# Altium schematic sheet Top.SchDoc
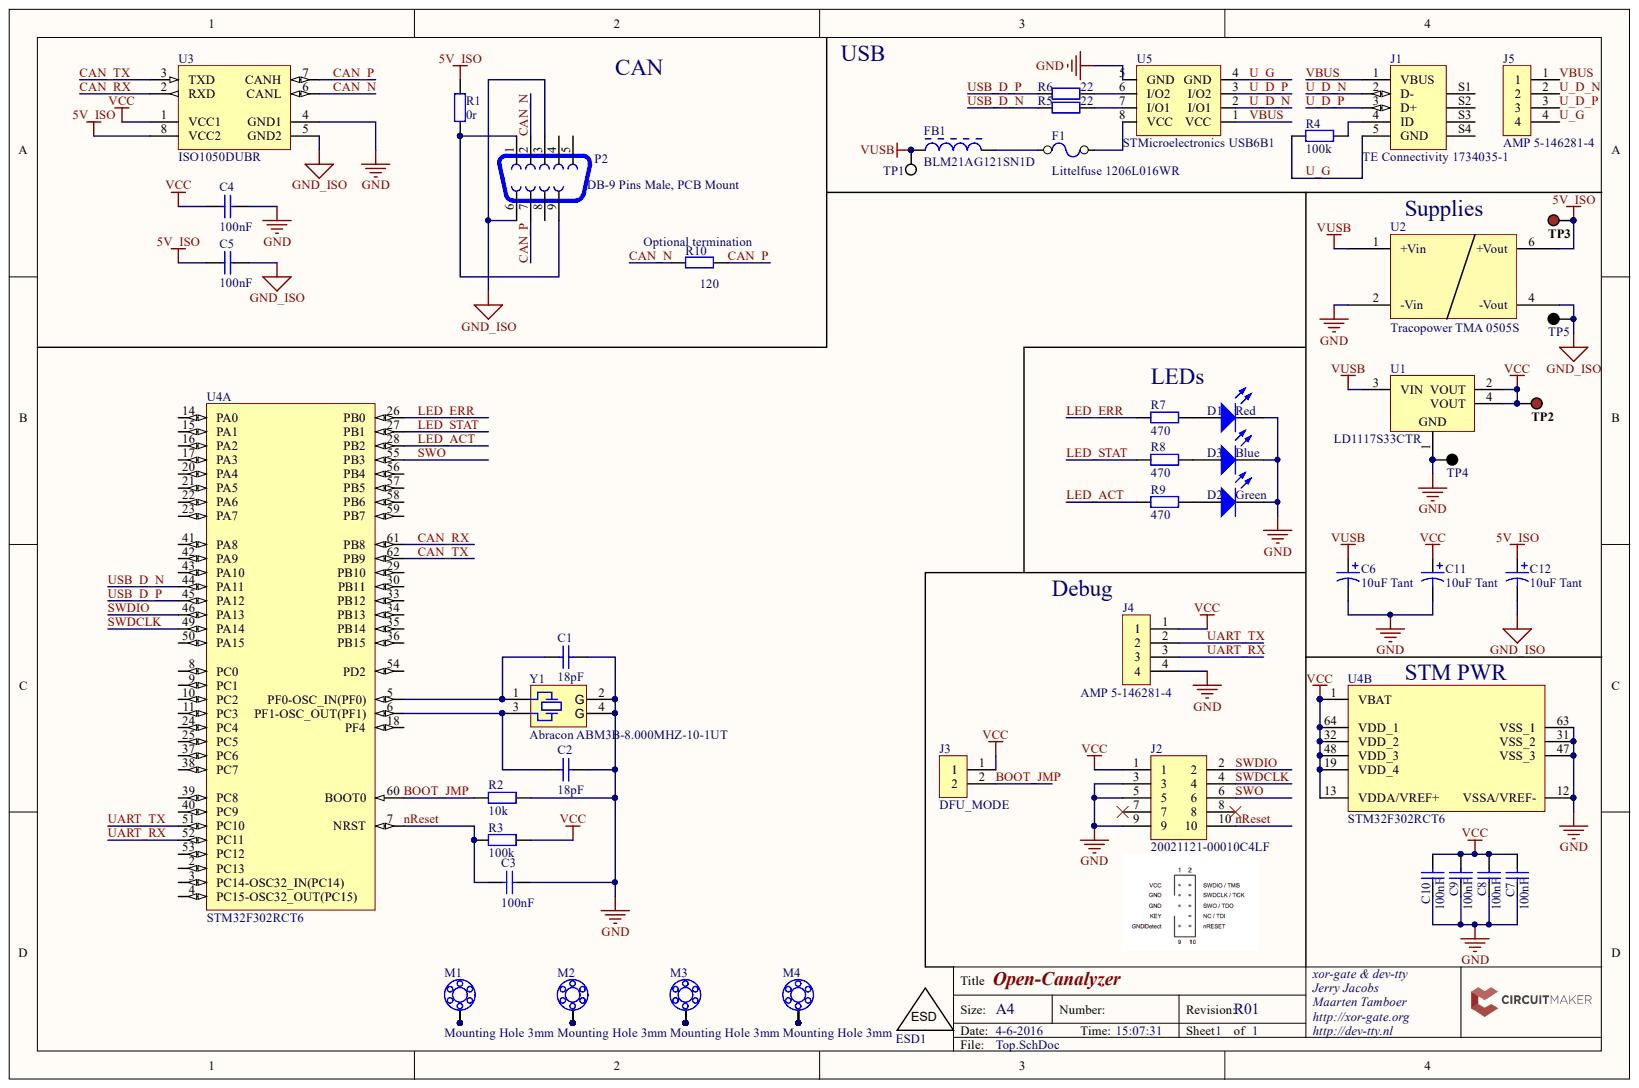
[diagram p0: Top.SchDoc]

## Parts
C1  18pF  [PCB-8u3bf2z8rexgmcet8v16-1]
C2  18pF  [PCB-8u3bf2z8rexgmcet8v16-1]
C3  100nF  [PCB-8u3bf2z8rexgmcet8v16-1]
C4  100nF  [PCB-8u3bf2z8rexgmcet8v16-1]
C5  100nF  [PCB-8u3bf2z8rexgmcet8v16-1]
C6  10uF Tant  [AVX-B]
C7  100nF  [PCB-8u3bf2z8rexgmcet8v16-1]
C8  100nF  [PCB-8u3bf2z8rexgmcet8v16-1]
C9  100nF  [PCB-8u3bf2z8rexgmcet8v16-1]
C10  100nF  [PCB-8u3bf2z8rexgmcet8v16-1]
C11  10uF Tant  [AVX-B]
C12  10uF Tant  [AVX-B]
D1  Red  [PCB-1071566-1]
D2  Green  [PCB-1544875-1]
D3  Blue  [PCB-8gfa86omdps060zikj8u-1]
ESD1  ESD  [PCB-1jlgxmw3o3eyoyl7wo9c-1]
F1  Littelfuse 1206L016WR  [CAPC3216X127X50LL20T25]
FB1  BLM21AG121SN1D  [MURA-BLM21-H1-CHIP-2_V]
J1  TE Connectivity 1734035-1  Manufacturer Part Number: 1734035-1  [PCB-92xbr658ff5005b6v25i-1]
J2  20021121-00010C4LF  [PCB-345154-1]
J3  DFU_MODE  [PCB-5yupddsicqn8qq7gr5lb-1]
J4  AMP 5-146281-4  Manufacturer Part Number: 5-146281-4  [PCB-ojd8tno4evkt907x0x3i-1]
J5  AMP 5-146281-4  Manufacturer Part Number: 5-146281-4  [PCB-ojd8tno4evkt907x0x3i-1]
M1  Mounting Hole 3mm  [Mounting Hole 3mm]
M2  Mounting Hole 3mm  [Mounting Hole 3mm]
M3  Mounting Hole 3mm  [Mounting Hole 3mm]
M4  Mounting Hole 3mm  [Mounting Hole 3mm]
P2  DB-9 Pins Male, PCB Mount  [PCB-t2r1bfvo6rsnmeykqezm-1]
R1  0r  [PCB-jbjp1sagbj5faggo6atp-1]
R2  10k  [PCB-jbjp1sagbj5faggo6atp-1]
R3  100k  [PCB-jbjp1sagbj5faggo6atp-1]
R4  100k  [PCB-jbjp1sagbj5faggo6atp-1]
R5  22  [PCB-jbjp1sagbj5faggo6atp-1]
R6  22  [PCB-jbjp1sagbj5faggo6atp-1]
R7  470  [PCB-jbjp1sagbj5faggo6atp-1]
R8  470  [PCB-jbjp1sagbj5faggo6atp-1]
R9  470  [PCB-jbjp1sagbj5faggo6atp-1]
R10  120  [PCB-jbjp1sagbj5faggo6atp-1]
TP1  Keystone Electronics 5002  [TEST_LOOP]  (value not drawn)
TP2  Keystone Electronics 5000  [PCB-gm3691gcwmiln8nb0eoq-1]  (value not drawn)  (no BOM)
TP3  Keystone Electronics 5000  [PCB-gm3691gcwmiln8nb0eoq-1]  (value not drawn)  (no BOM)
TP4  Keystone Electronics 5001  [PCB-v8mkaai4yvuvpumg75h8-1]  (value not drawn)
TP5  Keystone Electronics 5001  [PCB-v8mkaai4yvuvpumg75h8-1]  (value not drawn)
U1  LD1117S33CTR  [SOT-223_M]
U2  Tracopower TMA 0505S  Manufacturer Part Number: TMA 0505S  [PCB-a34l2xuwcfq5ykblouz8-1]
U3  ISO1050DUBR  [DUB8_M]
U4  STM32F302RCT6  [STM-LQFP64_M]  (parts A,B)
U5  STMicroelectronics USB6B1  [SO8_M]
Y1  Abracon ABM3B-8.000MHZ-10-1UT  [PCB-3272631-1]

## Nets
5V_ISO: C5.1 C12.1 R1.2 TP3.TP U2.6(+Vout) U3.8(VCC2)
GND: C1.2 C2.2 C3.1 C4.2 C6.2 C7.2 C8.2 C9.2 C10.2 C11.2 D1.K D2.K D3.K J2.3 J2.5 J2.9 J4.4 R2.2 TP4.1 U1.1 U2.2(-Vin) U3.4(GND1) U4.12(VSSA/VREF-) U4.31(VSS_2) U4.47(VSS_3) U4.63(VSS_1) U5.5(GND) Y1.2(G) Y1.4(G)
GND_ISO: C5.2 C12.2 P2.3 P2.6 TP5.1 U2.4(-Vout) U3.5(GND2)
VCC: C4.1 C7.1 C8.1 C9.1 C10.1 C11.1 J2.1 J3.1 J4.1 R3.2 TP2.TP U1.2(VOUT) U1.4(VOUT) U3.1(VCC1) U4.1(VBAT) U4.13(VDDA/VREF+) U4.19(VDD_4) U4.32(VDD_2) U4.48(VDD_3) U4.64(VDD_1)
VUSB: C6.1 FB1.1 TP1.TP U1.3(VIN) U2.1(+Vin)
BOOT_JMP: J3.2 R2.1 U4.60(BOOT0)
CAN_N: P2.2 R10.1 U3.6(CANL)
CAN_P: P2.7 R10.2 U3.7(CANH)
CAN_RX: U3.2(RXD) U4.61(PB8)
CAN_TX: U3.3(TXD) U4.62(PB9)
LED_ACT: R9.1 U4.28(PB2)
LED_ERR: R7.1 U4.26(PB0)
LED_STAT: R8.1 U4.27(PB1)
NRESET: C3.2 J2.10 R3.1 U4.7(NRST)
SWDCLK: J2.4 U4.49(PA14)
SWDIO: J2.2 U4.46(PA13)
SWO: J2.6 U4.55(PB3)
U_D_N: J1.2(D-) J5.2 U5.2(I/O1)
U_D_P: J1.3(D+) J5.3 U5.3(I/O2)
U_G: J1.5(GND) J5.4 R4.2 U5.4(GND)
UART_RX: J4.3 U4.52(PC11)
UART_TX: J4.2 U4.51(PC10)
USB_D_N: R5.1 U4.44(PA11)
USB_D_P: R6.1 U4.45(PA12)
VBUS: J1.1(VBUS) J5.1 U5.1(VCC)
NetC1_1: C1.1 U4.5(PF0-OSC_IN(PF0)) Y1.1
NetC2_1: C2.1 U4.6(PF1-OSC_OUT(PF1)) Y1.3
NetD1_A: D1.A R7.2
NetD2_A: D2.A R9.2
NetD3_A: D3.A R8.2
NetF1_1: F1.1 FB1.2
NetF1_2: F1.2 U5.8(VCC)
NetJ1_4: J1.4(ID) R4.1
NetM1_1: M1.1 M1.2 M1.3 M1.4 M1.5 M1.6 M1.7
NetM2_1: M2.1 M2.2 M2.3 M2.4 M2.5 M2.6 M2.7
NetM3_1: M3.1 M3.2 M3.3 M3.4 M3.5 M3.6 M3.7
NetM4_1: M4.1 M4.2 M4.3 M4.4 M4.5 M4.6 M4.7
NetP2_1: P2.1 P2.9 R1.1
NetR5_2: R5.2 U5.7(I/O1)
NetR6_2: R6.2 U5.6(I/O2)

Not connected: J1 S1 S2 S3 S4; J2 7 8; P2 4 5 8; U4 2(PC13) 3(PC14-OSC32_IN(PC14)) 4(PC15-OSC32_OUT(PC15)) 8(PC0) 9(PC1) 10(PC2) 11(PC3) 14(PA0) 15(PA1) 16(PA2) 17(PA3) 18(PF4) 20(PA4) 21(PA5) 22(PA6) 23(PA7) 24(PC4) 25(PC5) 29(PB10) 30(PB11) 33(PB12) 34(PB13) 35(PB14) 36(PB15) 37(PC6) 38(PC7) 39(PC8) 40(PC9) 41(PA8) 42(PA9) 43(PA10) 50(PA15) 53(PC12) 54(PD2) 56(PB4) 57(PB5) 58(PB6) 59(PB7)

## Library data of the parts
C1-C5 C7-C10 = CMP-8u3bf2z8rexgmcet8v16-A.1: Capacitor 0805; Component Kind: Standard; CustomComponentID: 8u3bf2z8rexgmcet8v16; Library Name: CommunityVault
C6 C11 C12 = CMP-6223aa0b3946bde4-1: Cap Tant Solid 10uF 25V B CASE 10% (3.5 X 2.8 X 1.9mm) SMD 3528-21 2.5 Ohm 125?C T/R; Manufacturer Part Number: TAJB106K025RNJ; Capacitance: 1e-05 F; Equivalent Series Resistance (ESR): 2.5 Ω; Lead-Free Status: Lead Free; Voltage Rating (DC): 25.0 V; Capacitance Tolerance: ±10%; Case/Package: 1210; Component Kind: Standard; Library Name: CommunityVault; Mounting Style: Surface Mount; OctopartID: 6223aa0b3946bde4; Octopart Url: http://octopart.com/tajb106k025rnj-avx-19037699; Packaging: Cut Tape (CT); REACH SVHC Compliance: No SVHC; RoHS: Compliant; Seller Landing  Url: https://octopart-clicks.com/click/track?country=US&ak=8157f166&sig=07e128a&sid=2402&ppid=19037699&vpid=84862021&ct=offers
D1 = CMP-1071566-3: DIALIGHT   5988110107F; Case/Package (SI): 2012; Forward Voltage: 2.2 V; Lead-Free Status: Lead Free; Lens Type: Non-Diffused; Luminous Intensity: 0.04 cd; Viewing Angle: 140 °; Wavelength: 6.35e-07 m; Case/Package: 0805; Color: Red; Component Kind: Standard; Library Name: CommunityVault; OctopartID: bcc375c4d97c6304; Octopart Url: https://octopart.com/598-8110-107f-dialight-868569; Packaging: Reel; RoHS: Compliant; Size-Height: 0.0007 m; Size-Length: 0.002 m; Size-Width: 0.00125 m
D2 = CMP-1544875-2: DIALIGHT   5988170107F; Case/Package (SI): 2012; Forward Voltage: 2.0 V; Lead-Free Status: Lead Free; Lens Type: Non-Diffused; Luminous Intensity: 0.02 cd; Viewing Angle: 140 °; Wavelength: 5.7e-07 m; Case/Package: 0805; Color: Green; Component Kind: Standard; Library Name: CommunityVault; OctopartID: c0130914a4641fd4; Octopart Url: https://octopart.com/598-8170-107f-dialight-868625; Packaging: Reel; RoHS: Compliant; Size-Height: 0.0007 m; Size-Length: 0.002 m; Size-Width: 0.00125 m
D3 = CMP-90265921f4414fe1-1: 598 Series Blue 2 x 1.25 x 0.7 mm 140° Water Clear 140 mcd 3.2 V SMT LED; Manufacturer Part Number: 598-8191-107F; Case/Package (SI): 2012; Forward Voltage: 3.20 V; Lead-Free Status: Lead Free; Lens Type: Non-Diffused; Luminous Intensity: 140 mcd; Wavelength: 475 nm, 473 nm; Case/Package: 0805; Color: Blue; Component Kind: Standard; Library Name: CommunityVault; Mounting Style: Surface Mount; Number of Pins: 2; OctopartID: 90265921f4414fe1; Octopart URL: http://octopart.com/598-8191-107f-dialight-868609; Packaging: Reel; RoHS: Compliant; Size-Height: 700 µm; Size-Length: 2.00 mm; Size-Width: 1.25 mm; Viewing Angle: 140 °
ESD1 = CMP-1jlgxmw3o3eyoyl7wo9c-A.1: ESD LOGO; Component Kind: Standard; CustomComponentID: 1jlgxmw3o3eyoyl7wo9c; Library Name: FavoritesLibrary
F1 = CMP-277446-4: PTC RESET 30V .160A SMD 1206; Holding Current: 0.16 A; Lead-Free Status: Lead Free; Operating Voltage: 30.0 V; Power Dissipation: 0.6 W; Tripping Current: 0.37 A; Component Kind: Standard; Library Name: CommunityVault; OctopartID: 16cadfca74c2c90d; Octopart Url: https://octopart.com/1206l016wr-littelfuse-673646; Packaging: Cut Tape (CT); REACH SVHC Compliance: No SVHC; RoHS: Compliant; Voltage Rating (DC): 30.0 V
FB1 = CMP-886678-3: Chip Ferrite Bead for General Signal lines, 120 Ohm, 800 mA, -55 to 125 degC, 2 x 1.25 x 1.05 mm SMD, Tape and Reel; Case/Package (SI): 2012; Current Rating: 0.2 A; Frequency: 100000000.0 Hz; Lead-Free Status: Lead Free; Number of Circuits: 1; Resistance: 120.0 Ω; Shielding: No; Case/Package: 0805; Component Kind: Standard; Library Name: CommunityVault; Mounting Style: Surface Mount; Number of Pins: 2; OctopartID: a2886b82370e7d4f; Octopart Url: https://octopart.com/blm21ag121sn1d-murata-45280; REACH SVHC Compliance: No SVHC; RoHS: Compliant; Size-Height: 0.00085 m; Size-Length: 0.002 m; Size-Width: 0.00125 m
J1 = CMP-778005-8: USB Connectors 5P MINI TYPE B RECPT SMT WITH POSTS; ELV Compliant: Compliant; Gender: Female; Lead-Free Status: Lead Free; Number of Contacts: 5; Component Kind: Standard; Contact Material: Phosphor Bronze; Flammability Rating: UL 94 V-0; Housing Color: Black; Housing Material: Thermoplastic; Library Name: CommunityVault; Lifecycle Status: Active; Number of Positions: 5; OctopartID: 9a20517374d345e7; Octopart Url: https://octopart.com/1734035-1-te+connectivity-39439253; Orientation: Right Angle; Packaging: Tray; REACH SVHC Compliance: No SVHC; RoHS: Compliant; Size-Height: 0.0039 m; Size-Length: 0.00845 m; Size-Width: 0.0077 m
J2 = CMP-345154-9: CONN HEADER 10POS DL UNSHRD SMD; Company: FCI; Gender: Male; Number of Contacts: 10; Component Kind: Standard; Contact Material: Copper Alloy; Contact Plating: Gold; Library Name: CommunityVault; OctopartID: f63c3ff63b2429fb; Octopart Url: https://octopart.com/20021121-00010c4lf-fci-12642430; REACH SVHC Compliance: No SVHC; RoHS: Compliant
J3 = CMP-2a80e1d15423f547-12: 2 Position header; Manufacturer Part Number: 3-644456-2; ELV Compliant: Compliant; Gender: Male; Lead-Free Status: Lead Free; Mounting Angle: 180 °; Number of Contacts: 2; Pin Pitch: 2.54 mm; Shrouded: No; Voltage Rating (AC): 250 V; Component Kind: Standard; Contact Material: Copper Alloy; Contact Plating: Tin; Flammability Rating: UL 94 V-0; Housing Color: Natural; Housing Material: Polyester; Library Name: CommunityVault; Lifecycle Status: Active; Mounting Style: Through Hole; Number of Positions: 2; Number of Rows: 1; OctopartID: 2a80e1d15423f547; Octopart URL: http://octopart.com/3-644456-2-te+connectivity-39541701; Orientation: Vertical; Packaging: Box, Bag; RoHS: Compliant; Size-Height: 2.54 mm; Size-Length: 4.78 mm; Size-Width: 2.34 mm
J4 J5 = CMP-6813a3747affb7d4-2: Conn Unshrouded Header HDR 4 POS 2.54mm Solder ST Thru-Hole; ELV Compliant: Compliant; Lead-Free Status: Lead Free; Component Kind: Standard; Contact Material: Copper Alloy; Contact Plating: Tin, Tin over Nickel, Gold; Flammability Rating: UL 94 V-0; Housing Color: Black; Library Name: CommunityVault; Lifecycle Status: Active; Mounting Style: PCB; OctopartID: 6813a3747affb7d4; Octopart URL: http://octopart.com/5-146281-4-te+connectivity+%2F+amp-40712074; Orientation: Vertical; RoHS: Compliant
M1-M4 = CMP-gm1nkr018x6871exes7y-A.1: Component Kind: Standard; CustomComponentID: gm1nkr018x6871exes7y; Description: Mounting Hole 3mm; Library Name: FavoritesLibrary
P2 = CMP-00accaeb48cadf6b-11: D-Sub Connector Right Angle 9 Pins, Male Stamped Pin, PCB Mount with 7.2mm Footprint; Manufacturer Part Number: 1734354-1; ELV Compliant: Compliant; Lead-Free Status: Lead Free; Number of Contacts: 9; Number of Mating Cycles: 100; Pin Pitch: 0.00277 m; Component Kind: Standard; Contact Material: Phosphor Bronze; Contact Plating: Gold Flash, Tin; ES: http://www.es.co.th/detail.asp?Prod=000712914; Flammability Rating: UL 94 V-0; Gender: Male; Housing Color: Black; Housing Material: Nylon; Library Name: CommunityVault; Lifecycle Status: Active; Material: Metal; Mounting Style: Through Hole; Number of Positions: 9; Number of Rows: 2; OctopartID: 00accaeb48cadf6b; Octopart URL: http://octopart.com/1734354-1-te+connectivity-55405824; Orientation: Right Angle; Packaging: Tray, Box; REACH SVHC Compliance: No SVHC; RoHS: Compliant; Size-Height: 0.0125 m; Size-Length: 0.0308 m; Size-Width: 0.0184 m
R1-R10 = CMP-jbjp1sagbj5faggo6atp-A.2: Resistor 0805; Component Kind: Standard; CustomComponentID: jbjp1sagbj5faggo6atp; Library Name: CommunityVault
TP1 = CMP-954155-6: KEYSTONE   5002   Series:-; Manufacturer Part Number: 5002; Lead-Free Status: Lead Free; Color: White; Component Kind: Standard; Contact Material: Phosphor Bronze; Contact Plating: Tin; Library Name: CommunityVault; Manufacturer: Keystone; OctopartID: f34a3a40c2652c8d; Octopart Url: https://octopart.com/5002-keystone-5193; Packaging: Bag; REACH SVHC Compliance: No SVHC; RoHS: Compliant; Size-Diameter: 2.54 mm; Size-Height: 7.62 mm; Size-Inner Diameter: 1.27 mm; Size-Length: 7.62 mm
TP2 TP3 = CMP-954153-15: KEYSTONE   5000   TEST POINT, PCB, THROUGH HOLE; Manufacturer Part Number: 5000; Lead-Free Status: Lead Free; Color: Red; Contact Material: Phosphor Bronze; Library Name: CommunityVault; Manufacturer: Keystone; OctopartID: c16f0865c3341ea8; Octopart Url: https://octopart.com/5000-keystone-10045; Packaging: Bag; REACH SVHC Compliance: No SVHC; RoHS: Compliant; Size-Diameter: 2.54 mm; Size-Height: 7.62 mm; Size-Inner Diameter: 1.27 mm; Size-Length: 7.62 mm
TP4 TP5 = CMP-954154-12: KEYSTONE   5001   TEST POINT, PCB, THROUGH HOLE; Manufacturer Part Number: 5001; Lead-Free Status: Lead Free; Color: Black; Component Kind: Standard; Contact Material: Phosphor Bronze; Contact Plating: Tin; Library Name: CommunityVault; Manufacturer: Keystone; OctopartID: cd3f0435fb0b12f8; Octopart Url: https://octopart.com/5001-keystone-4946; Packaging: Bulk; REACH SVHC Compliance: No SVHC; RoHS: Compliant; Size-Diameter: 2.54mm; Size-Height: 7.62mm; Size-Inner Diameter: 1.27mm; Size-Length: 7.62mm
U1 = CMP-1170392-2: Fixed Low Drop Positive Voltage Regulator, 3.3V, 4-Pin SOT-223, Tape and Reel; Dropout Voltage: 1.1 V; Lead-Free Status: Lead Free; Number of Outputs: 1; Case/Package: SOT-223; Component Kind: Standard; Library Name: CommunityVault; Lifecycle Status: Active; Mounting Style: Surface Mount; Number of Pins: 3; OctopartID: 0754ce562bb7d893; Octopart Url: https://octopart.com/ld1117s33ctr-stmicroelectronics-526725; Output Voltage: 3.3 V; REACH SVHC Compliance: No SVHC; RoHS: Compliant
U2 = CMP-fec637b9144e2101-2: Tma Series 1w \ Input Range ±10%, i/o Isolation 1000 Vdc \ Sip-7 Package (Plastic Case); Input Voltage (DC): 5.0 V; Isolation Voltage: 1000.0 V; Number of Outputs: 1; Output Current: 0.2 A; Power Rating: 1.0 W; Component Kind: Standard; Flammability Rating: UL 94 V-0; Library Name: CommunityVault; Mounting Style: Through Hole; OctopartID: fec637b9144e2101; Octopart URL: http://octopart.com/tma+0505s-tracopower-7720942; Output Voltage: 5.0 V; REACH SVHC Compliance: No SVHC; RoHS: Compliant; Size-Height: 0.0102 m; Size-Width: 0.0061 m; Supply Voltage (DC): 5.0 V; Weight: 2.6 g
U3 = CMP-1158484-2: Isolated CAN Transceiver, -55 to 105 degC, 8-pin SOP (DUB), Green (RoHS & no Sb/Br); Data Rate: 1000000.0 bps; Drivers Per Package: 1; Lead-Free Status: Lead Free; Output Current: 0.07 A; Output Voltage: 5.0 V; Thermal Shutdown: Yes; Case/Package: SOP; Component Kind: Standard; Library Name: CommunityVault; Lifecycle Status: Active; Mounting Style: Surface Mount; Number of Pins: 8; OctopartID: 915958f0b6204e9e; Octopart Url: https://octopart.com/iso1050dubr-texas+instruments-10682911; Packaging: Cut Tape (CT); REACH SVHC Compliance: No SVHC; RoHS: Compliant
U4 = CMP-5648437-3: ARM Cortex-M4 32-bit MCU, 256 KB Flash, 32 KB Internal RAM, 52 I/Os, 64-pin LQFP, -40 to 85 degC, Tray; Clock Speed: 72000000.0 Hz; Lead-Free Status: Lead Free; RAM Memory Size: 32768 B; Component Kind: Standard; Library Name: CommunityVault; Lifecycle Status: Active; Number of Pins: 64; OctopartID: 6977a1fa814bb6b0; Octopart Url: https://octopart.com/stm32f302rct6-stmicroelectronics-25677146; REACH SVHC Compliance: No SVHC; RoHS: Compliant
U5 = CMP-302241-3: IC USB PORT PROTECTION 8-SOIC; Breakdown Voltage: 6.0 V; Capacitance: 2.5e-11 F; Lead-Free Status: Lead Free; Case/Package: SOIC; Component Kind: Standard; Library Name: CommunityVault; Lifecycle Status: Active; Mounting Style: Surface Mount; Number of Pins: 8; OctopartID: 1cebd5e68d40c32c; Octopart Url: https://octopart.com/usb6b1-stmicroelectronics-505037; Packaging: Tube; REACH SVHC Compliance: No SVHC; RoHS: Compliant
Y1 = CMP-3272631-2: Crystals 8.0MHz 10pf 10ppm -10C +60C; Load Capacitance: 1e-11 F; Component Kind: Standard; Library Name: CommunityVault; OctopartID: 12bddaab2e63cd7a; Octopart Url: https://octopart.com/abm3b-8.000mhz-10-1ut-abracon-18618808; RoHS: Compliant
